# Altium schematic sheet ESP32_USB_C.SchDoc
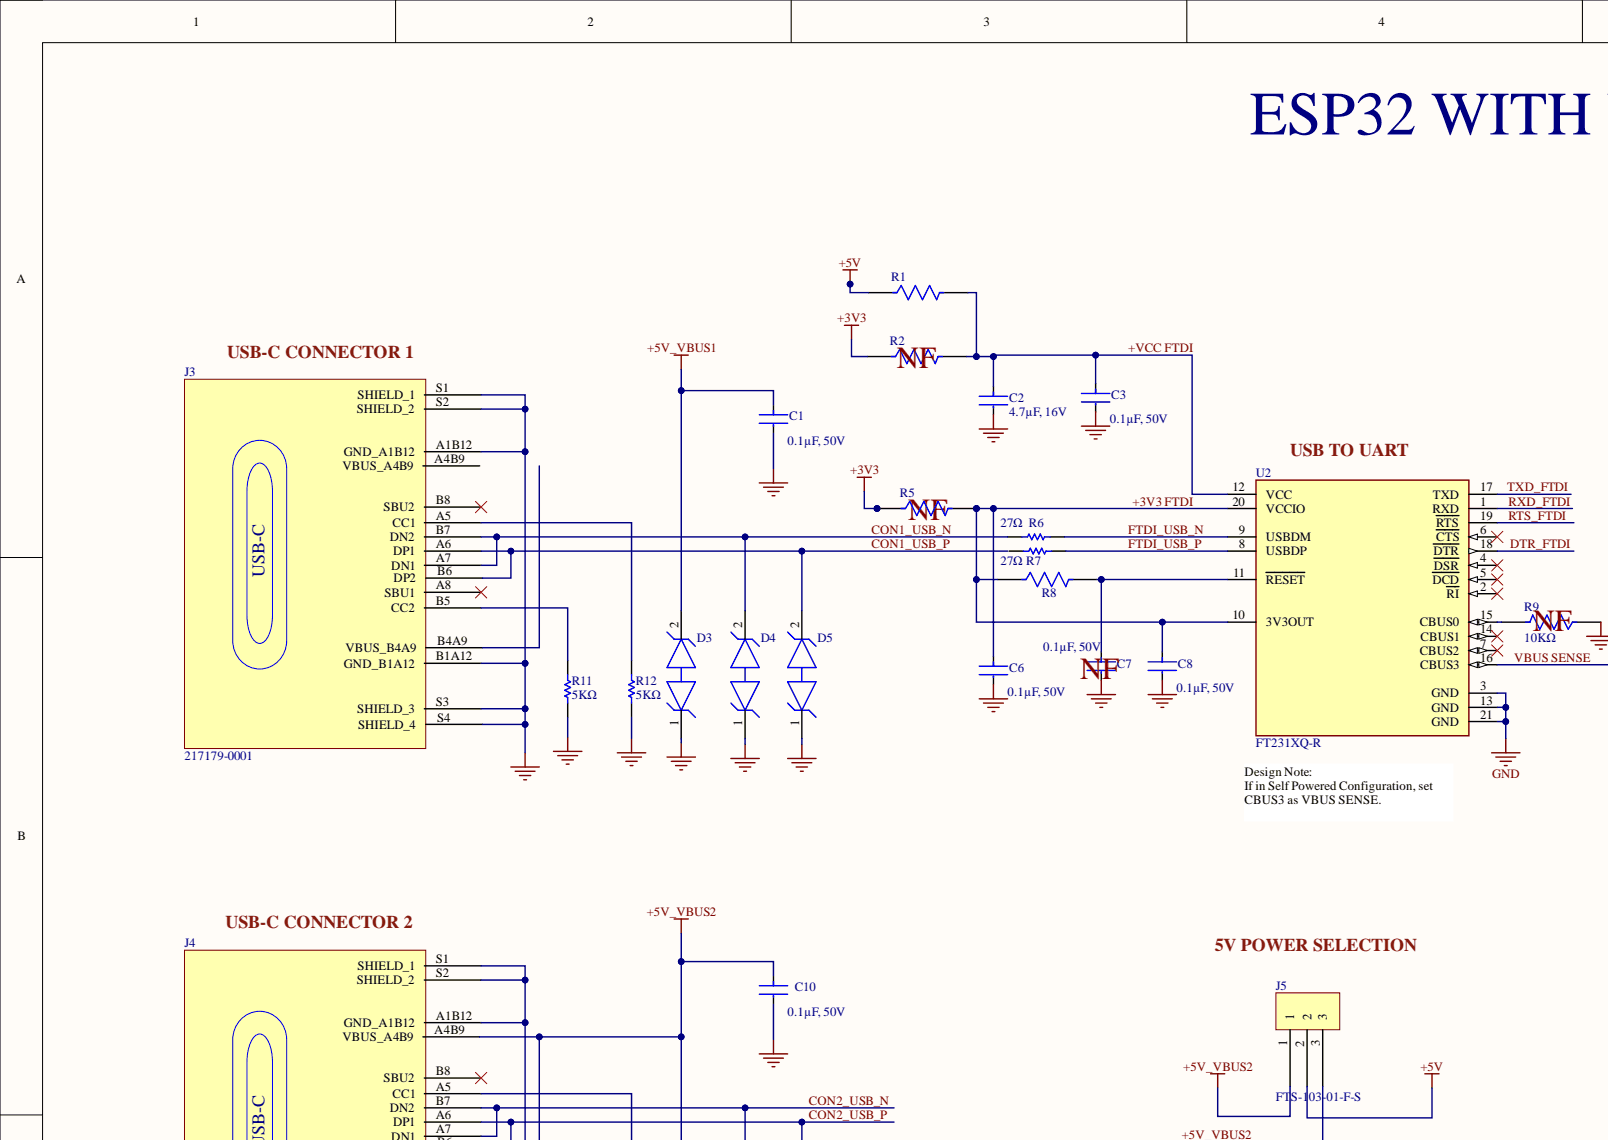
[diagram p0: ESP32_USB_C.SchDoc, top left]
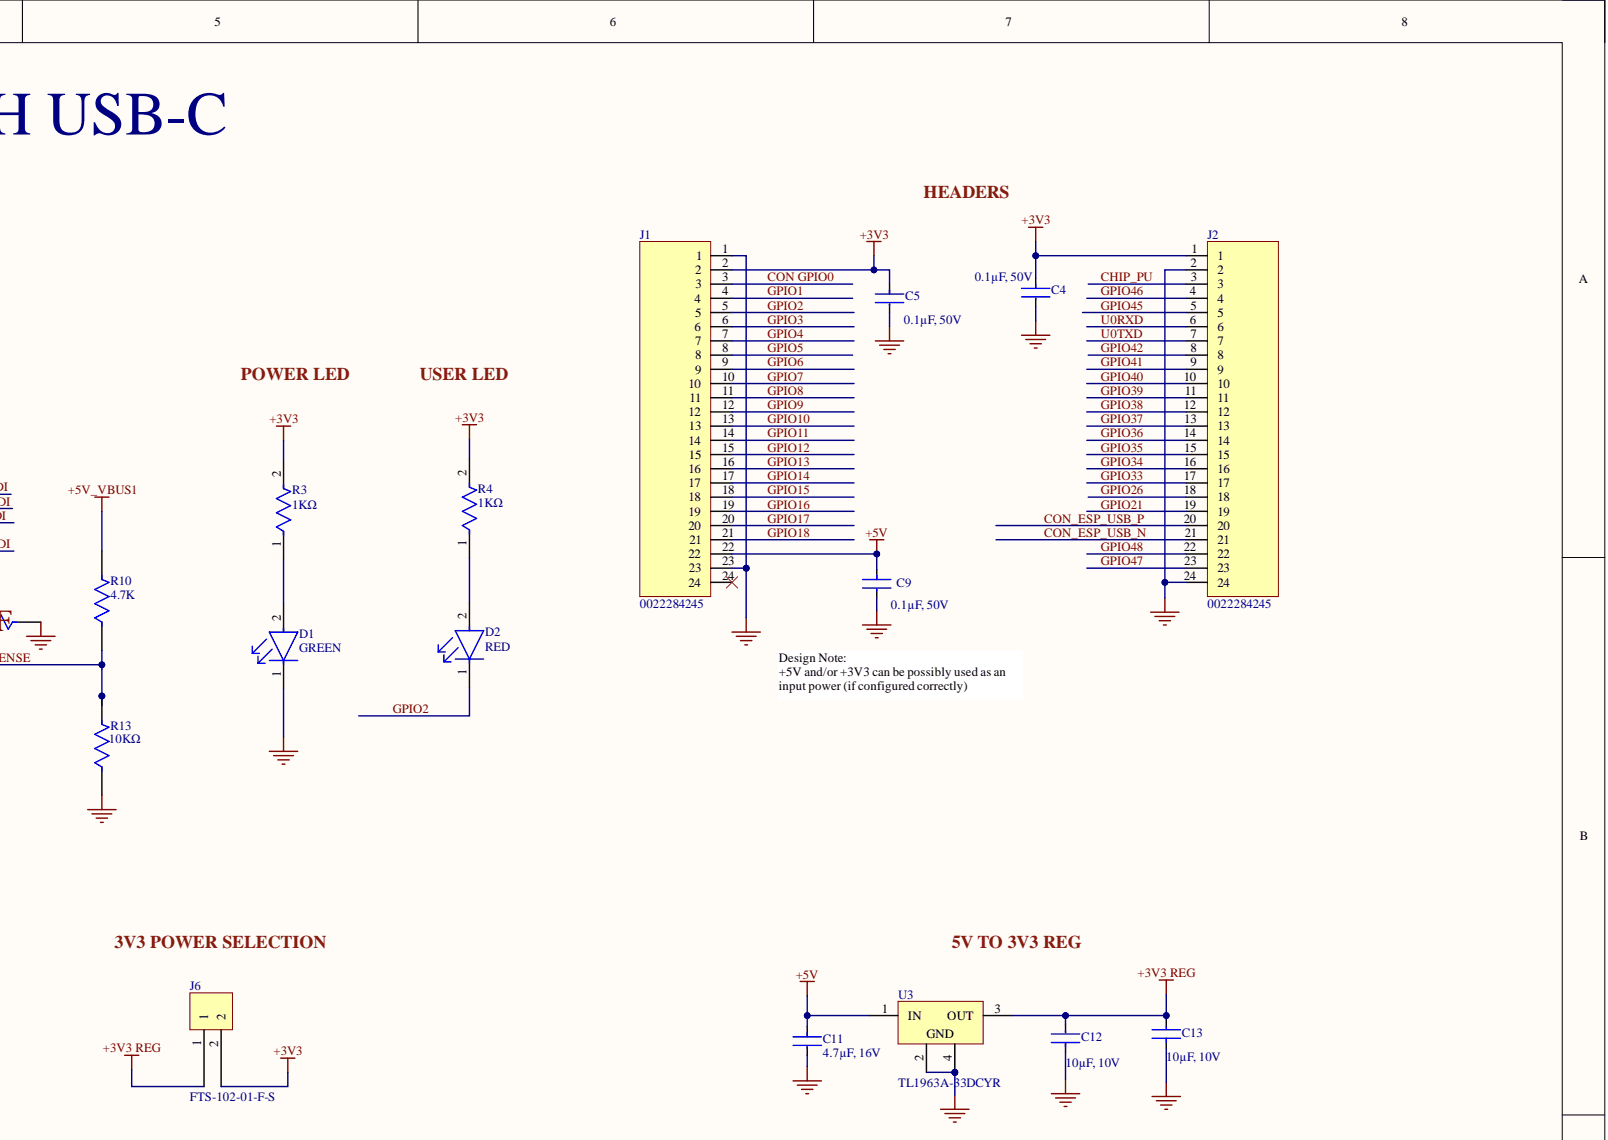
[diagram p1: ESP32_USB_C.SchDoc, top right]
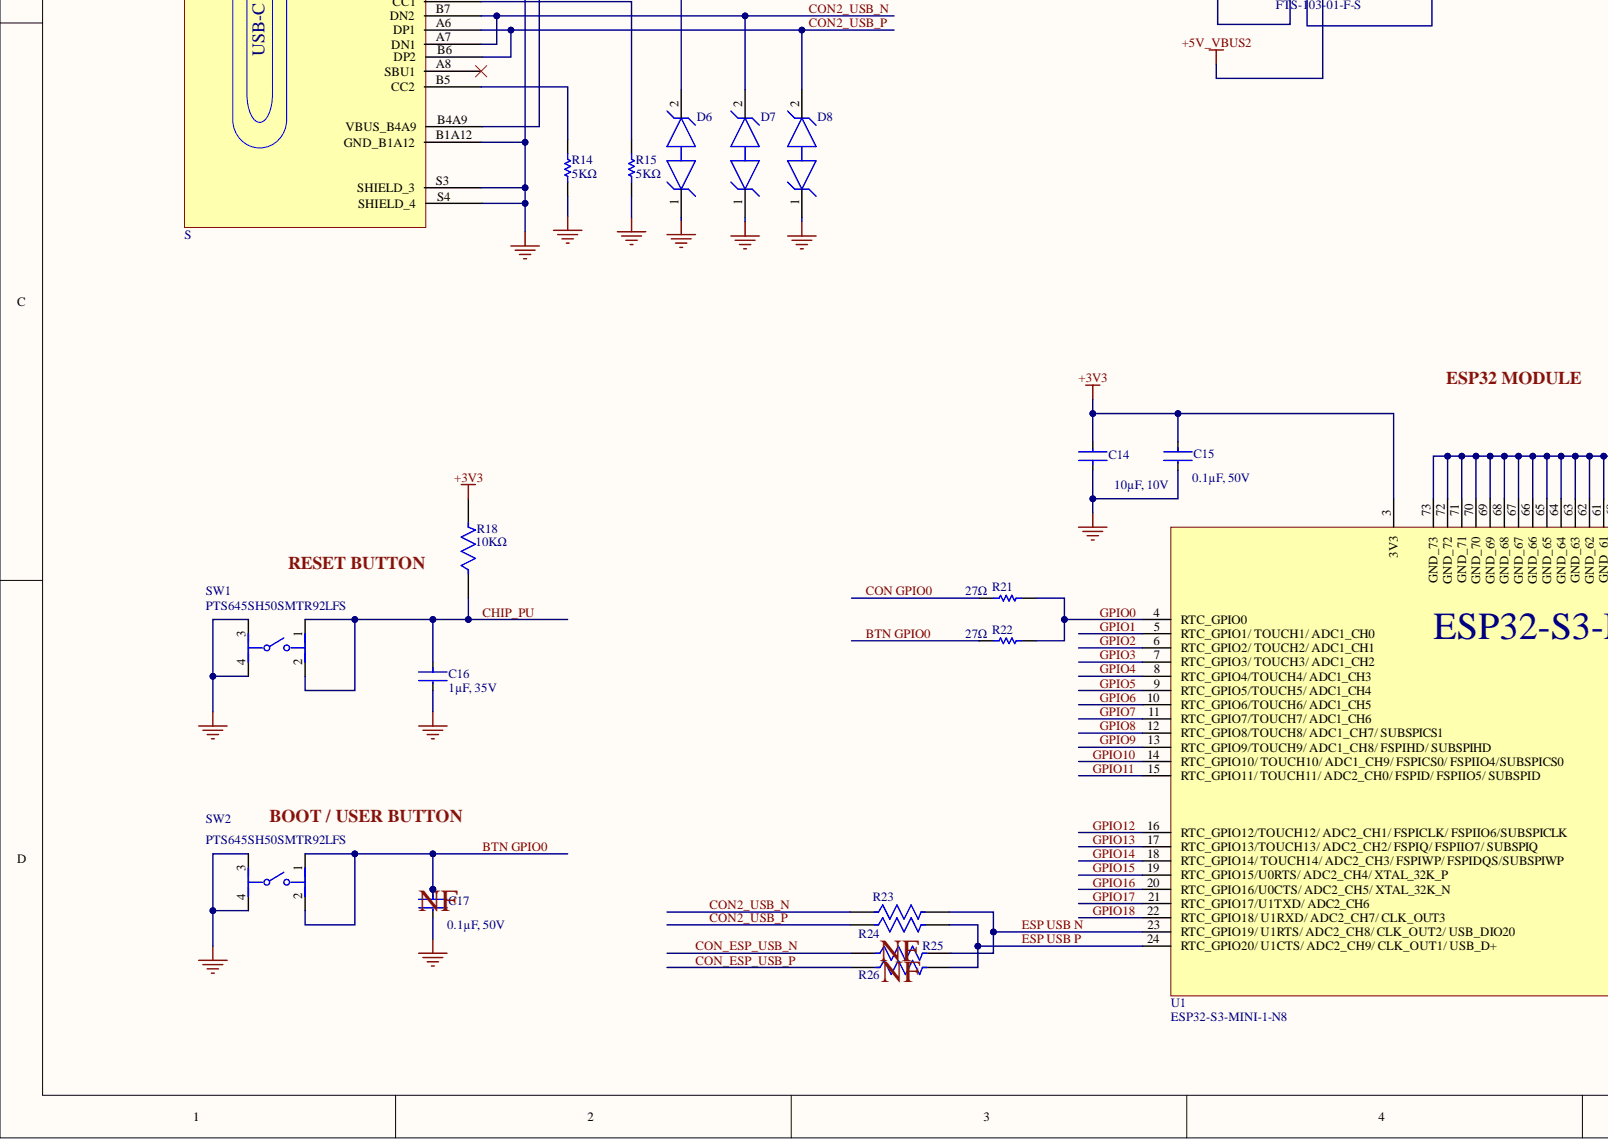
[diagram p2: ESP32_USB_C.SchDoc, bottom left]
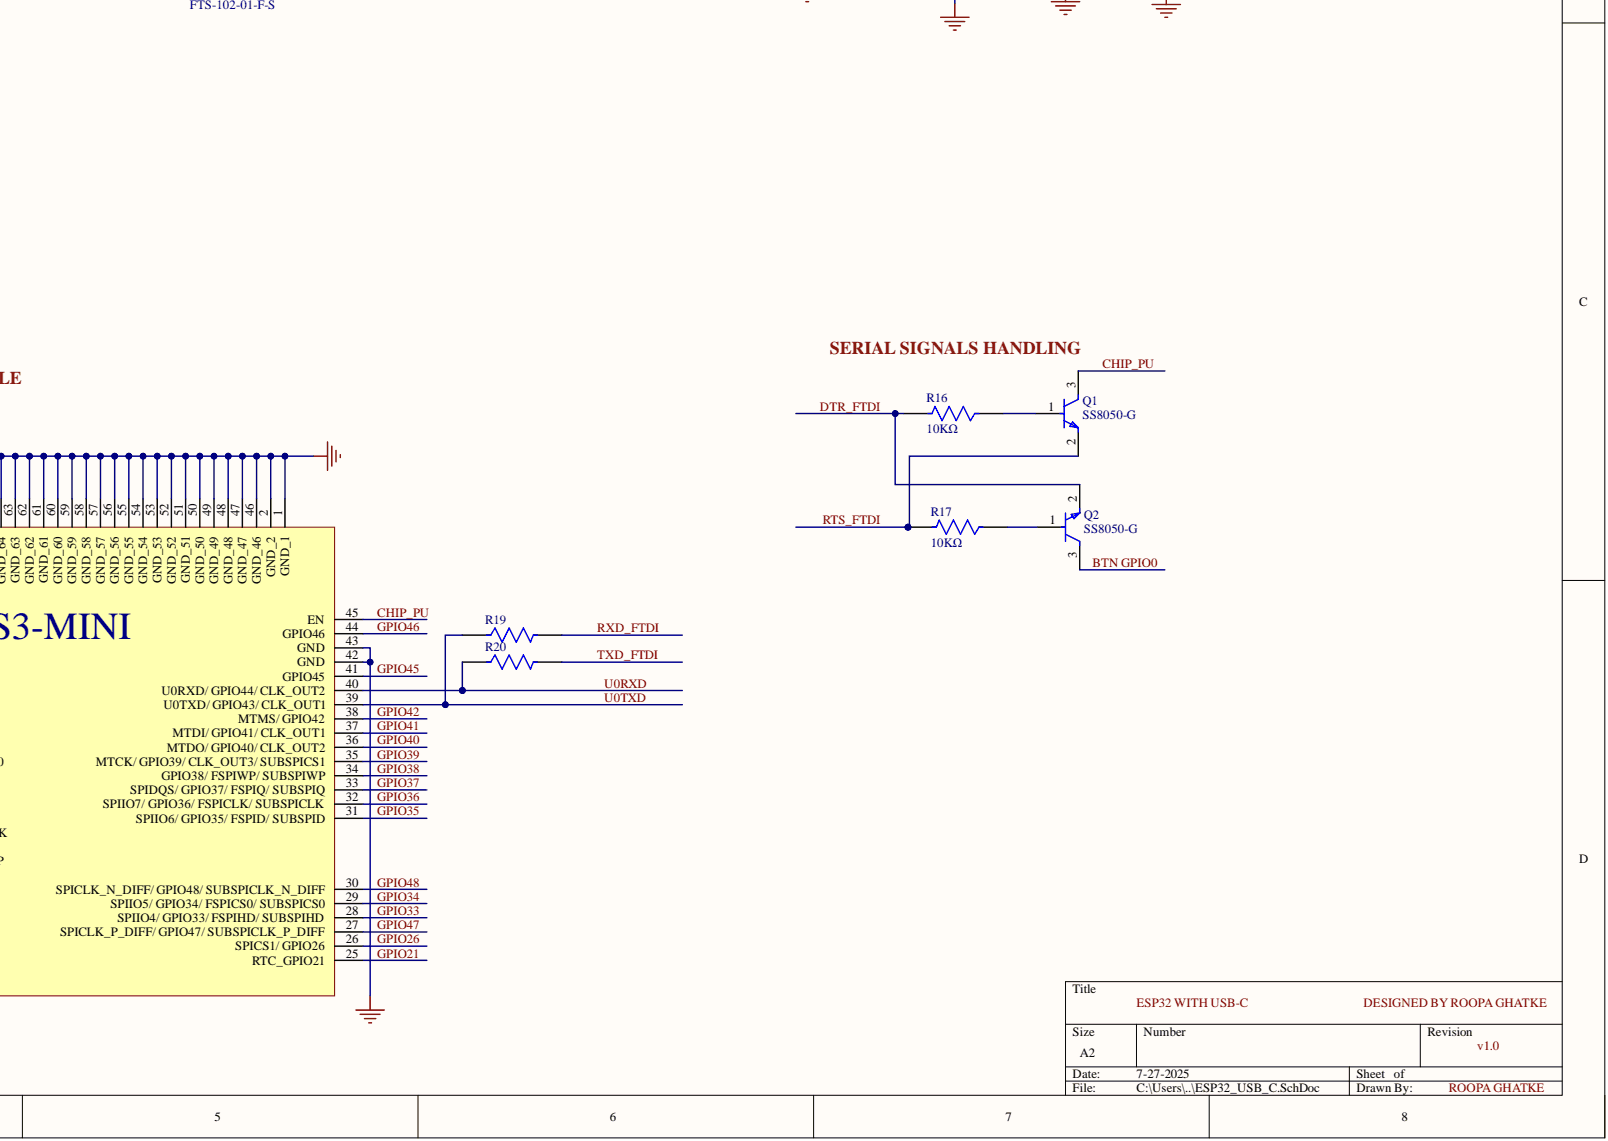
[diagram p3: ESP32_USB_C.SchDoc, bottom right]

## Parts
C1  0.1µF, 50V  [FP-CL779-IPC_C]
C2  4.7µF, 16V  [FP-CL829-IPC_C]
C3  0.1µF, 50V  [FP-CL779-IPC_C]
C4  0.1µF, 50V  [FP-CL779-IPC_C]
C5  0.1µF, 50V  [FP-CL779-IPC_C]
C6  0.1µF, 50V  [FP-CL779-IPC_C]
C7  0.1µF, 50V  [FP-CL779-IPC_C]
C8  0.1µF, 50V  [FP-CL779-IPC_C]
C9  0.1µF, 50V  [FP-CL779-IPC_C]
C10  0.1µF, 50V  [FP-CL779-IPC_C]
C11  4.7µF, 16V  [FP-CL829-IPC_C]
C12  10µF, 10V  Voltage Rating: 10V  [FP-CL07-IPC_C]
C13  10µF, 10V  Voltage Rating: 10V  [FP-CL07-IPC_C]
C14  10µF, 10V  [FP-CL07-IPC_C]
C15  0.1µF, 50V  [FP-CL779-IPC_C]
C16  1µF, 35V  Voltage Rating: 35V  [FP-CL440-IPC_C]
C17  0.1µF, 50V  [FP-CL779-IPC_C]
D1  GREEN  [FP-6_541-5056_01-4-MFG]
D2  RED  [FP-SML-P1-MFG]
D3  SD36C-01FTG  [FP-SD-C-MFG]  (value not drawn)
D4  SD36C-01FTG  [FP-SD-C-MFG]  (value not drawn)
D5  SD36C-01FTG  [FP-SD-C-MFG]  (value not drawn)
D6  SD36C-01FTG  [FP-SD-C-MFG]  (value not drawn)
D7  SD36C-01FTG  [FP-SD-C-MFG]  (value not drawn)
D8  SD36C-01FTG  [FP-SD-C-MFG]  (value not drawn)
J1  0022284245  [HRD_1X24_2.54MM]
J2  0022284245  [HRD_1X24_2.54MM]
J3  217179-0001  [MOLEX_2171790001]
J4  S  [MOLEX_2171790001]
J5  FTS-103-01-F-S  [HRD_1X3_1.27MM]
J6  FTS-102-01-F-S  [HRD_1X2_1.27MM]
Q1  SS8050-G  [FP-SS8050-G-MFG]
Q2  SS8050-G  [FP-SS8050-G-MFG]
R1  RC0402FR-070RL  [FP-RC0402-0_4-IPC_A]  (value not drawn)
R2  RC0402FR-070RL  [FP-RC0402-0_4-IPC_A]  (value not drawn)
R3  1KΩ  [FP-RC0402-0_4-IPC_A]
R4  1KΩ  [FP-RC0402-0_4-IPC_A]
R5  RC0402FR-070RL  [FP-RC0402-0_4-IPC_A]  (value not drawn)
R6  27Ω  [RESC1005X40X25LL05T10]
R7  27Ω  [RESC1005X40X25LL05T10]
R8  RC0402FR-070RL  [FP-RC0402-0_4-IPC_A]  (value not drawn)
R9  10KΩ  [FP-AC0402-MFG]
R10  4.7K  [FP-RT0402-MFG]
R11  5KΩ  [RESC1005X40X25NL05T10]
R12  5KΩ  [RESC1005X40X25NL05T10]
R13  10KΩ  [FP-AC0402-MFG]
R14  5KΩ  [RESC1005X40X25NL05T10]
R15  5KΩ  [RESC1005X40X25NL05T10]
R16  10KΩ  [FP-AC0402-MFG]
R17  10KΩ  [FP-AC0402-MFG]
R18  10KΩ  [FP-AC0402-MFG]
R19  RC0402FR-070RL  [FP-RC0402-0_4-IPC_A]  (value not drawn)
R20  RC0402FR-070RL  [FP-RC0402-0_4-IPC_A]  (value not drawn)
R21  27Ω  [RESC1005X40X25LL05T10]
R22  27Ω  [RESC1005X40X25LL05T10]
R23  RC0402FR-070RL  [FP-RC0402-0_4-IPC_A]  (value not drawn)
R24  RC0402FR-070RL  [FP-RC0402-0_4-IPC_A]  (value not drawn)
R25  RC0402FR-070RL  [FP-RC0402-0_4-IPC_A]  (value not drawn)
R26  RC0402FR-070RL  [FP-RC0402-0_4-IPC_A]  (value not drawn)
SW1  PTS645SH50SMTR92LFS  [FP-PTS645SH50SMTR92LFS-MFG]
SW2  PTS645SH50SMTR92LFS  [FP-PTS645SH50SMTR92LFS-MFG]
U1  ESP32-S3-MINI-1-N8  [XCVR_ESP32-S3-MINI-1-N8]
U2  FT231XQ-R  Interface: UART  [FP-QFN-20-MFG]
U3  TL1963A-33DCYR  [DCY0004A_N]

## Nets
+3V3: C4.C4 C5.1 C14.2 C15.1 J1.2 J2.1 J6.2 R2.1 R3.2 R4.2 R5.1 R18.2 U1.3(3V3)
+3V3 REG: C12.2 C13.2 J6.1 U3.3(OUT)
+5V: C9.1 C11.1 J1.22 J5.2 R1.1 U3.1(IN)
+5V_VBUS1: C1.C1 D3.2 R10.2
+5V_VBUS2: C10.1 D6.2 J4.A4B9(VBUS_A4B9) J4.B4A9(VBUS_B4A9) J5.1 J5.3
GND: C1.2 C2.2 C3.2 C4.2 C5.2 C6.2 C7.2 C8.2 C9.2 C10.2 C11.2 C12.1 C13.1 C14.1 C15.2 C16.2 C17.2 D1.1 D3.1 D4.1 D5.1 D6.1 D7.1 D8.1 J1.1 J1.23 J2.2 J2.24 J3.A1B12(GND_A1B12) J3.B1A12(GND_B1A12) J3.S1(SHIELD_1) J3.S2(SHIELD_2) J3.S3(SHIELD_3) J3.S4(SHIELD_4) J4.A1B12(GND_A1B12) J4.B1A12(GND_B1A12) J4.S1(SHIELD_1) J4.S2(SHIELD_2) J4.S3(SHIELD_3) J4.S4(SHIELD_4) R9.1 R11.1 R12.1 R13.1 R14.1 R15.1 SW1.3 SW1.4 SW2.3 SW2.4 U1.1(GND_1) U1.2(GND_2) U1.42(GND) U1.43(GND) U1.46(GND_46) U1.47(GND_47) U1.48(GND_48) U1.49(GND_49) U1.50(GND_50) U1.51(GND_51) U1.52(GND_52) U1.53(GND_53) U1.54(GND_54) U1.55(GND_55) U1.56(GND_56) U1.57(GND_57) U1.58(GND_58) U1.59(GND_59) U1.60(GND_60) U1.61(GND_61) U1.62(GND_62) U1.63(GND_63) U1.64(GND_64) U1.65(GND_65) U1.66(GND_66) U1.67(GND_67) U1.68(GND_68) U1.69(GND_69) U1.70(GND_70) U1.71(GND_71) U1.72(GND_72) U1.73(GND_73) U2.3(GND) U2.13(GND) U2.21(GND) U3.2 U3.4
+3V3 FTDI: C6.1 C8.1 R5.2 R8.1 U2.10(3V3OUT) U2.20(VCCIO)
+VCC FTDI: C2.1 C3.1 R1.2 R2.2 U2.12(VCC)
BTN GPIO0: C17.1 Q2.3 R22.1 SW2.1 SW2.2
CHIP_PU: C16.1 J2.3 Q1.3 R18.1 SW1.1 SW1.2 U1.45(EN)
CON1_USB_N: D4.2 J3.A7(DN1) J3.B7(DN2) R6.1
CON1_USB_P: D5.2 J3.A6(DP1) J3.B6(DP2)
CON2_USB_N: D7.2 J4.A7(DN1) J4.B7(DN2) R23.1
CON2_USB_P: D8.2 J4.A6(DP1) J4.B6(DP2) R24.1
CON GPIO0: J1.3 R21.1
CON_ESP_USB_N: J2.21 R25.1
CON_ESP_USB_P: J2.20 R26.1
DTR_FTDI: Q2.2 R16.2 U2.18(DTR)
ESP USB N: R23.2 R25.2 U1.23(RTC_GPIO19/_U1RTS/_ADC2_CH8/_CLK_OUT2/_USB_DIO20)
ESP USB P: R24.2 R26.2 U1.24(RTC_GPIO20/_U1CTS/_ADC2_CH9/_CLK_OUT1/_USB_D+)
FTDI_USB_N: R6.2 U2.9(USBDM)
FTDI_USB_P: R7.2 U2.8(USBDP)
GPIO0: R21.2 R22.2 U1.4(RTC_GPIO0)
GPIO1: J1.4 U1.5(RTC_GPIO1/_TOUCH1/_ADC1_CH0)
GPIO2: D2.1 J1.5 U1.6(RTC_GPIO2/_TOUCH2/_ADC1_CH1)
GPIO3: J1.6 U1.7(RTC_GPIO3/_TOUCH3/_ADC1_CH2)
GPIO4: J1.7 U1.8(RTC_GPIO4/TOUCH4/_ADC1_CH3)
GPIO5: J1.8 U1.9(RTC_GPIO5/TOUCH5/_ADC1_CH4)
GPIO6: J1.9 U1.10(RTC_GPIO6/TOUCH6/_ADC1_CH5)
GPIO7: J1.10 U1.11(RTC_GPIO7/TOUCH7/_ADC1_CH6)
GPIO8: J1.11 U1.12(RTC_GPIO8/TOUCH8/_ADC1_CH7/_SUBSPICS1)
GPIO9: J1.12 U1.13(RTC_GPIO9/TOUCH9/_ADC1_CH8/_FSPIHD/_SUBSPIHD)
GPIO10: J1.13 U1.14(RTC_GPIO10/_TOUCH10/_ADC1_CH9/_FSPICS0/_FSPIIO4/SUBSPICS0)
GPIO11: J1.14 U1.15(RTC_GPIO11/_TOUCH11/_ADC2_CH0/_FSPID/_FSPIIO5/_SUBSPID)
GPIO12: J1.15 U1.16(RTC_GPIO12/TOUCH12/_ADC2_CH1/_FSPICLK/_FSPIIO6/SUBSPICLK)
GPIO13: J1.16 U1.17(RTC_GPIO13/TOUCH13/_ADC2_CH2/_FSPIQ/_FSPIIO7/_SUBSPIQ)
GPIO14: J1.17 U1.18(RTC_GPIO14/_TOUCH14/_ADC2_CH3/_FSPIWP/_FSPIDQS/SUBSPIWP)
GPIO15: J1.18 U1.19(RTC_GPIO15/U0RTS/_ADC2_CH4/_XTAL_32K_P)
GPIO16: J1.19 U1.20(RTC_GPIO16/U0CTS/_ADC2_CH5/_XTAL_32K_N)
GPIO17: J1.20 U1.21(RTC_GPIO17/U1TXD/_ADC2_CH6)
GPIO18: J1.21 U1.22(RTC_GPIO18/_U1RXD/_ADC2_CH7/_CLK_OUT3)
GPIO21: J2.19 U1.25(RTC_GPIO21)
GPIO26: J2.18 U1.26(SPICS1/_GPIO26)
GPIO33: J2.17 U1.28(SPIIO4/_GPIO33/_FSPIHD/_SUBSPIHD)
GPIO34: J2.16 U1.29(SPIIO5/_GPIO34/_FSPICS0/_SUBSPICS0)
GPIO35: J2.15 U1.31(SPIIO6/_GPIO35/_FSPID/_SUBSPID)
GPIO36: J2.14 U1.32(SPIIO7/_GPIO36/_FSPICLK/_SUBSPICLK)
GPIO37: J2.13 U1.33(SPIDQS/_GPIO37/_FSPIQ/_SUBSPIQ)
GPIO38: J2.12 U1.34(GPIO38/_FSPIWP/_SUBSPIWP)
GPIO39: J2.11 U1.35(MTCK/_GPIO39/_CLK_OUT3/_SUBSPICS1)
GPIO40: J2.10 U1.36(MTDO/_GPIO40/_CLK_OUT2)
GPIO41: J2.9 U1.37(MTDI/_GPIO41/_CLK_OUT1)
GPIO42: J2.8 U1.38(MTMS/_GPIO42)
GPIO45: J2.5 U1.41(GPIO45)
GPIO46: J2.4 U1.44(GPIO46)
GPIO47: J2.23 U1.27(SPICLK_P_DIFF/_GPIO47/_SUBSPICLK_P_DIFF)
GPIO48: J2.22 U1.30(SPICLK_N_DIFF/_GPIO48/_SUBSPICLK_N_DIFF)
RTS_FTDI: Q1.2 R17.2 U2.19(RTS)
RXD_FTDI: R19.2 U2.1(RXD)
TXD_FTDI: R20.2 U2.17(TXD)
U0RXD: J2.6 R20.1 U1.40(U0RXD/_GPIO44/_CLK_OUT2)
U0TXD: J2.7 R19.1 U1.39(U0TXD/_GPIO43/_CLK_OUT1)
VBUS SENSE: R10.1 R13.2 U2.16(CBUS3)
NetC7_1: C7.1 R8.2 U2.11(RESET)
NetD1_2: D1.2 R3.1
NetD2_2: D2.2 R4.1
NetJ3_A5: J3.A5(CC1) R12.2
NetJ3_B5: J3.B5(CC2) R11.2
NetJ4_A5: J4.A5(CC1) R15.2
NetJ4_B5: J4.B5(CC2) R14.2
NetQ1_1: Q1.1 R16.1
NetQ2_1: Q2.1 R17.1
NetR9_2: R9.2 U2.15(CBUS0)

Not connected: J1 24; J3 A4B9(VBUS_A4B9) A8(SBU1) B4A9(VBUS_B4A9) B8(SBU2); J4 A8(SBU1) B8(SBU2); R7 1; U2 2(RI) 4(DSR) 5(DCD) 6(CTS) 7(CBUS2) 14(CBUS1)

## Library data of the parts
C1 C3-C10 C15 C17 = CMP-13271-002336-1: Cap Ceramic 100nF 50V X7R ±10% SMD 0402 +125°C Paper T/R; Component Kind: Standard; Library Name: Altium Content Vault
C2 C11 = CMP-13271-001468-1: Cap Ceramic 4.7uF 16V X5R ±20% SMD 0402 +85°C Paper T/R; Capacitance: 4.7uF; Case Code (Imperial): 0402; Case Code (Metric): 1005; Case/Package: 0402; Component Kind: Standard; Length: 0.039inch; Library Name: Altium Content Vault; Max Operating Temperature: 85°C; Min Operating Temperature: -55°C; Mount: Surface Mount; Packaging: Tape and Reel; Thickness: 0.022inch; Tolerance: 20%; Voltage Rating: 16V; Width: 0.02inch
C12-C14 = CMP-13271-002778-1: Cap Ceramic 10uF 10V X5R ±20% SMD 0402 +85°C Paper T/R; Capacitance: 10uF; Case Code (Imperial): 0402; Case Code (Metric): 1005; Case/Package: 0402; Component Kind: Standard; Length: 0.039inch; Library Name: Altium Content Vault; Max Operating Temperature: 85°C; Min Operating Temperature: -55°C; Mount: Surface Mount; Packaging: Tape and Reel; Thickness: 0.035inch; Tolerance: 20%; Width: 0.02inch
  C14: Voltage Rating: 10V
C16 = CMP-13271-000031-1: Cap Ceramic 1uF 35V X5R ±10% SMD 0402 +85°C Paper T/R; Capacitance: 1uF; Case Code (Imperial): 0402; Case Code (Metric): 1005; Case/Package: 0402; Component Kind: Standard; Length: 0.039inch; Library Name: Altium Content Vault; Max Operating Temperature: 85°C; Min Operating Temperature: -55°C; Mount: Surface Mount; Packaging: Tape and Reel; Thickness: 0.022inch; Tolerance: 10%; Width: 0.02inch
D1 = CMP-02520-000009-1: LED GREEN 0603 SMD; Manufacturer: Vishay; Manufacturer Part Number: TLMP1100-GS08; Automotive Grade: Grade 3; Colour: Pure green; Forward Current: 20mA; Forward Voltage: 2.1V; Led Orientation: Top View; Luminous Intensity: 15mcd; Max Junction Temp: +120°C; Peak Emmision Wavelength: 562nm; Power Dissipation: 90mW; Reverse Voltage: 6V; Viewing Angle: 160°; Wavelength: 561nm; Ambient Temperature Range High: +100°C; Ambient Temperature Range Low: -40°C; Automotive: Yes; Category: Diode; Component Kind: Standard; ComponentLink2Description: Datasheet; ComponentLink2URL: http://www.vishay.com/docs/83173/tlmb1100.pdf; Device Class L1: Optoelectronics; Device Class L2: LEDs; Device Class L3: unset; Digikey Description: LED GREEN 0603 SMD; Height: 0.65mm; Lead Free: Yes; Library Name: Altium Content Vault; Mouser Description: Standard LEDs - SMD Pure Green; Octopart: https://octopart.com/tlmp1100-gs08-vishay-55433993; Package: 6.541-5056.01-4; RoHS: TRUE; Temperature: to +120°C; Temperature Range High: +120°C
D2 = CMP-08952-000002-1: LED RED CLEAR 0402 SMD; Manufacturer: Rohm; Manufacturer Part Number: SML-P12VTT86R; Forward Current: 20mA; Forward Voltage: 2V; Led Orientation: Top View; Luminous Intensity: 60mcd; Power Dissipation: 50mW; Reverse Voltage: 5V; Wavelength: 630nm; Automotive: No; Category: Diode; Colour: Red; Component Kind: Standard; ComponentLink2Description: Datasheet; ComponentLink2URL: https://www.rohm.com/datasheet/SML-P12VT(R)/sml-p1-e; Device Class L1: Optoelectronics; Device Class L2: LEDs; Device Class L3: unset; Digikey Description: LED RED CLEAR 0402 SMD; Height: 0.25mm; Lead Free: Yes; Library Name: Altium Content Vault; Mouser Description: SML-P1 Series Red 0402 Clear 60 mcd 2V Surface Mount PICOLED? LED; Octopart: https://octopart.com/sml-p12vtt86r-rohm-34112315; Package: SML-P1; RoHS: TRUE; Temperature: -40°C to +85°C; Temperature Range High: +85°C; Temperature Range Low: -40°C
D3-D8 = CMP-08607-000051-1: TVS DIODE 36V 60V SOD323; Manufacturer: Littelfuse; Automotive Grade: Grade 1; Breakdown Voltage: 40V; Capacitance: 30pF; Direction: Bidirectional; Number Of Channels: 1; Peak Pulse Current: 5A; Peak Pulse Power: 450W; Standoff Height: 0mm; Value: 30pF; Automotive: Yes; Category: Diode; Clamping Voltage: 60V; Component Kind: Standard; ComponentLink2Description: Datasheet; ComponentLink2URL: https://www.littelfuse.com/~/media/electronics/datasheets/tvs_diode_arrays/littelfuse_tvs_diode_array_sd_c_datasheet.pdf.pdf; Device Class L1: Circuit Protection; Device Class L2: TVS Diodes; Device Class L3: unset; Digikey Description: TVS DIODE 36V 60V SOD323; Height: 1.14mm; Ipc Land Pattern Name: SOD257130X97-2; Lead Free: Yes; Library Name: Altium Content Vault; Manufacturer Part Number: SD36C-01FTG; Mouser Description: In a Pack of 25, Littelfuse SD36C-01FTG, Bi-Directional TVS Diode Array, 450W, 2-Pin SOD-323; Octopart: https://octopart.com/sd36c-01ftg-littelfuse-46949624; Package: SD-C; Reverse Standoff Voltage: 36V; RoHS: TRUE; Temperature: -40°C to +125°C; Temperature Range High: +125°C; Temperature Range Low: -40°C
J1 J2 = 0022284245: CONN HEADER VERT 24POS 2.54MM; Manufacturer: Molex; Current Rating: 4A; Gender: Male; Number of Circuits: 24; Plating: Tin; Category: Headers and Wire Housings; Component Kind: Standard; ComponentLink1Description: https://datasheet.ciiva.com/6814/022284363-sd-6814049.pdf?src-supplier=Digikey; ComponentLink1URL: https://datasheet.ciiva.com/6814/022284363-sd-6814049.pdf?src-supplier=Digikey; ComponentLink2Description: https://datasheet.ciiva.com/10075/0022284245-pcb-headers-10075617.pdf?src-supplier=Avnet; ComponentLink2URL: https://datasheet.ciiva.com/10075/0022284245-pcb-headers-10075617.pdf?src-supplier=Avnet; ComponentLink3Description: https://datasheet.ciiva.com/1433/1597854-1433759.pdf?src-supplier=Element14; ComponentLink3URL: https://datasheet.ciiva.com/1433/1597854-1433759.pdf?src-supplier=Element14; Contact Material: Brass; Contact Plating: Gold; Housing Color: Black; LCSC Part Number: C7145888; Lead Free: Lead Free; Library Name: Schlib.SchLib; Manufacturer Part Number: 22-28-4245; Mounting Technology: Through Hole; Number of Contacts: 24; Number of Positions: 24; Number of Rows: 1; Orientation: Vertical; Packaging: Bulk; Pitch: 2.54mm; Pricing: 1=1.21, 10=1.024, 100=0.8699, 500=0.77638, 1000=0.73932, 5000=0.65998, 10000=0.62851 (USD); Reach SVHC Compliant: 4188SVHC; RoHS: No; Stock: 1546; Supplier: Digikey; Supplier Part Number: 900-0022284245-ND; Termination: Solder
J3 J4 = 217179-0001: USB Connector, USB Type C, USB 2.0, Receptacle, 16 Ways, Surface Mount, Through Hole Mount; Manufacturer: Molex; Current Rating: 1.25A; Number of Contacts: 16; USB Standard: USB 2.0; Voltage Rating: 30V; Category: Connectors; Component Kind: Standard; Contact Material: Nickel,Gold; Gender: Receptacle; Height: 3.36mm; LCSC Part Number: C3197684; Library Name: Schlib.SchLib; Manufacturer Part Number: 217179-0001; Max Operating Temperature: 85°C; Min Operating Temperature: -40°C; Pricing: 1=0.83, 10=0.704, 25=0.6596, 50=0.628, 100=0.598, 250=0.56052, 500=0.53374 (USD); RoHS: No; Stock: 21544; Supplier: Digikey; Supplier Part Number: 900-2171790001CT-ND
J5 = FTS-103-01-F-S: CONN HEADER VERT 3POS 1.27MM; Component Kind: Standard; LCSC Part Number: C3333578; Library Name: Schlib.SchLib
J6 = FTS-102-01-F-S: CONN HEADER VERT 2POS 1.27MM; Component Kind: Standard; LCSC Part Number: C3333578; Library Name: Schlib.SchLib
Q1 Q2 = CMP-20235-000001-1: NPN TRANSISTOR 1.5A 40V SOT-23 R; Collector Emitter Breakdown Voltage: 25V; Collector Emitter Voltage (VCEO): 400mV; Max Power Dissipation: 300mW; Transition Frequency: 100MHz; Case/Package: TO-236-3; Component Kind: Standard; Library Name: Altium Content Vault; Max Breakdown Voltage: 25V; Max Collector Current: 1.5A; Mount: Surface Mount; Packaging: Tape and Reel; RoHS Compliant: Yes
R1 R2 R5 R8 R19 R20 R23-R26 = CMP-2002-07342-2: RES SMD 0 OHM JUMPER 1/16W 0402; Max Power Dissipation: 63mW; Power Rating: 62.5mW; Resistance: 0R; Temperature Coefficient: 200ppm/°C; Tolerance: 1%; Voltage Rating: 50V; Case Code (Imperial): 0402; Case Code (Metric): 1005; Case/Package: 0402; Component Kind: Standard; Contact Plating: Tin; Features: Moisture Resistant; Height: 0.35mm; Lead Free: Lead Free; Length: 1mm; Library Name: Altium Content Vault; Max Operating Temperature: 155°C; Min Operating Temperature: -55°C; Mount: Surface Mount; Number of Terminations: 2; Packaging: Tape and Reel; Radiation Hardening: No; Resistor Type: Thick Film; RoHS Compliant: Yes; Series: RC; Size Code: 0402; Termination: SMD/SMT; Width: 0.5mm
R3 R4 = CMP-2002-07472-3: RES 1K OHM 1% 1/16W 0402; Max Power Dissipation: 63mW; Power Rating: 62.5mW; Resistance: 1kR; Temperature Coefficient: 100ppm/°C; Voltage Rating: 50V; Case Code (Imperial): 0402; Case Code (Metric): 1005; Case/Package: 0402; Component Kind: Standard; Construction: Rectangular; Depth: 0.5mm; Features: Moisture Resistant; Height: 0.32mm; Lead Free: Lead Free; Length: 1mm; Library Name: Altium Content Vault; Max Operating Temperature: 155°C; Military Standard: Not; Min Operating Temperature: -55°C; Number of Pins: 2; Number of Terminations: 2; Packaging: Tape and Reel; Reach SVHC Compliant: Yes; Resistance Tolerance: 1%; Resistor Type: Thick Film; RoHS Compliant: Yes; Series: RC; Size Code: 0402; Technology: THICK FILM; Termination: SMD/SMT; Tolerance: 1%; Voltage Rating (DC): 50V; Width: 0.5mm
R6 R7 R21 R22 = CMP-2002-07055-1: Manufacturer: Yageo / Phycomp; Part Number: RC0402FR-0727RL; Component Kind: Standard; Library Name: Altium Content Vault
R9 R13 R16-R18 = CMP-2002-01790-3: RES 10kΩ ±1% 0.063W 0402; Max Power Dissipation: 63mW; Power Rating: 62.5mW; Resistance: 10kR; Temperature Coefficient: 100ppm/°C; Voltage Rating: 50V; Case Code (Imperial): 0402; Case Code (Metric): 1005; Case/Package: 0402; Component Kind: Standard; Construction: Rectangular; Depth: 0.5mm; Features: Moisture Resistant; Height: 0.015inch; Lead Free: Lead Free; Length: 0.039inch; Library Name: Altium Content Vault; Max Operating Temperature: 155°C; Military Standard: Not; Min Operating Temperature: -55°C; Number of Terminations: 2; Packaging: Tape and Reel; Resistor Type: Thick Film; RoHS Compliant: Yes; Series: AC; Size Code: 0402; Termination: SMD/SMT; Tolerance: 1%; Width: 0.02inch
R10 = CMP-03412-002579-1: RES SMD 4.7K OHM 0.1% 1/16W 0402; Manufacturer: Yageo; Manufacturer Part Number: RT0402BRB074K7L; Power Rating: 0.063W; Resistance: 4.7KΩ; Temperature Coefficient: 10 ppm/°C; Tolerance: 0.001; Value: 4.7KΩ; Voltage Rating: 50V; Automotive: No; Category: Res; Component Kind: Standard; ComponentLink2Description: Datasheet; ComponentLink2URL: http://www.yageo.com/documents/recent/PYu-RT_1-to-0.01_RoHS_L_9.pdf; Composition: Thin Film; Device Class L1: Passive Components; Device Class L2: Resistors; Device Class L3: Chip SMD Resistors; Digikey Description: RES SMD 4.7K OHM 0.1% 1/16W 0402; Footprint Url: http://www.yageo.com/exep/pages/download/literatures/PYu-R_Mount_10.pdf; Height: 0.35mm; Ipc Land Pattern Name: RESC10050X30; Lead Free: Yes; Library Name: Altium Content Vault; Mouser Description: Thin Film Resistors 1/16W 4.7K ohm .1% 10ppm; Octopart: https://octopart.com/rt0402brb074k7l-yageo-71392583; Package: RT0402; RoHS: TRUE; Series: RT; Temperature: -55°C to +155°C; Temperature Range High: +155°C; Temperature Range Low: -55°C
R11 R12 R14 R15 = CMP-2002-07753-1: Manufacturer: Yageo / Phycomp; Part Number: RC0402FR-075K1L; Component Kind: Standard; Library Name: Altium Content Vault
SW1 SW2 = CMP-10040-000028-1: SWITCH TACTILE SPST-NO 0.05A 12V; Actuator Material: Nylon; Actuator Type: Standard; Current Rating: 50mA; Illumination Type: Non-Illuminated; Mechanical Life: 100000Cycles; Operating Force: 200gf; Switch Function: Off-Mom; Throw Configuration: SPST; Case/Package: SMD/SMT; Color: Black; Component Kind: Standard; Contact Material: Bronze; Contact Plating: Silver; Depth: 9mm; Lead Free: Lead Free; Length: 6mm; Library Name: Altium Content Vault; Max Current Rating: 50mA; Max Operating Temperature: 60°C; Max Voltage Rating (DC): 12V; Min Operating Temperature: -20°C; Mount: Surface Mount; Orientation: Straight; Package Quantity: 1000; Packaging: Tape and Reel; REACH SVHC: No SVHC; Termination: Gull Wing; Voltage Rating (DC): 12V
U1 = ESP32-S3-MINI-1-N8: SMD module, ESP32-S3FN8, 8 MB SPI flash, PCB antenna; Manufacturer: Espressif Systems; Category: LDO; Component Kind: Standard; ComponentLink1Description: https://datasheet.octopart.com/ESP32-S3-MINI-1-N8-Espressif-Systems-datasheet-188867343.pdf?src-supplier=TME; ComponentLink1URL: https://datasheet.octopart.com/ESP32-S3-MINI-1-N8-Espressif-Systems-datasheet-188867343.pdf?src-supplier=TME; LCSC Part Number: C2913206; Library Name: Schlib.SchLib; Manufacturer Part Number: ESP32-S3-MINI-1-N8; Pricing: 1=6.15, 10=5.5, 50=4.31, 100=4.19, 1000=3.65, 10000=3.44 (USD); RoHS: No; Stock: 9784; Supplier: Mouser; Supplier Part Number: 356-ESP32-S3-MINI1N8
U2 = CMP-0248-00001-3: IC USB SERIAL FULL UART 20QFN; Data Rate: 12Mbps; Max Supply Voltage: 5.5V; Min Supply Voltage: 2.97V; Number of Channels: 1; Operating Supply Current: 8mA; Case/Package: QFN; Component Kind: Standard; Lead Free: Lead Free; Library Name: Altium Content Vault; Max Operating Temperature: 85°C; Min Operating Temperature: -40°C; Mount: Surface Mount; Number of Pins: 20; Package Quantity: 5000; Packaging: Tape and Reel; Weight: 0.004339oz
U3 = CMP-0395-00535-2: Single Output Low Noise LDO, 1.5 A, Fixed 3.3 V Output, 2.1 to 20 V Input, 4-pin SOT-223 (DCY), -40 to 125 degC, Green (RoHS & no Sb/Br); Manufacturer: Texas Instruments; Accuracy(%): 3; Fixed Output Options(V): 3.3; Iout(Max)(A): 1.5; Iq(Typ)(mA): 1; SubFamily: Single Channel LDO; Vdo(Typ)(mV): 340; Vin(Max)(V): 20; Vin(Min)(V): 2.1; Code_JEDEC: TO-261; Component Kind: Standard; ComponentLink1Description: Manufacturer URL; ComponentLink1URL: http://www.ti.com/; ComponentLink2Description: Datasheet; ComponentLink2URL: http://www.ti.com/general/docs/lit/getliterature.tsp?genericPartNumber=TL1963A-33&fileType=pdf; ComponentLink3Description: Package Specification; ComponentLink3URL: http://www.ti.com/litv/pdf/mpds094a; DatasheetVersion: SLVS719F; Eco-Plan: Green (RoHS & no Sb/Br); Library Name: Altium Content Vault; Mounting Technology: Surface Mount; PackageDescription: 4-Pin Plastic Small Outline, Body 3.5 x 6.5 mm, Pitch 2.3 mm; PackageReference: DCY0004A; PackageVersion: 4202506/B; PartNumber: TL1963A-33DCYR; Vout Adj(Max)(V): 3.3; Vout Adj(Min)(V): 3.3
